# Altium schematic sheet 04-PS2.SchDoc
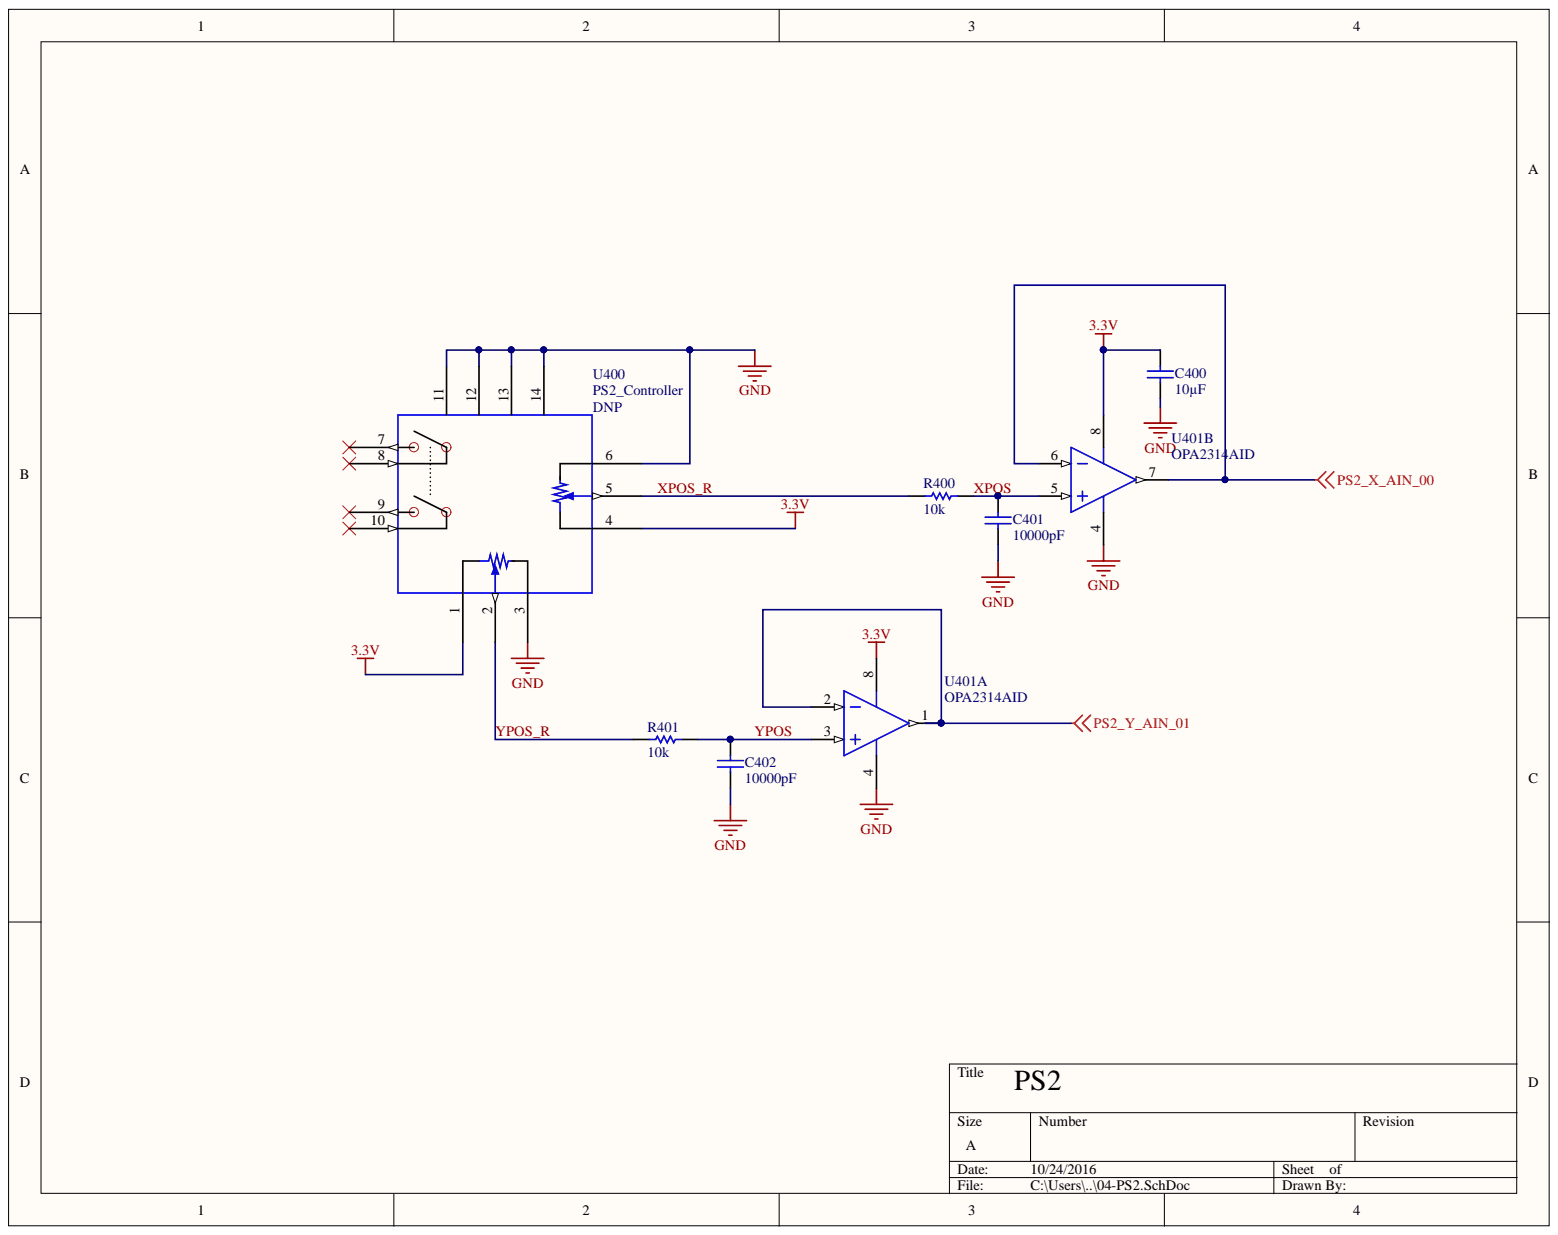
[diagram p0: 04-PS2.SchDoc]

## Parts
C400  10µF  [0805]
C401  10000pF  [CAP_0805]
C402  10000pF  [CAP_0805]
R400  10k  [RES_0805]
R401  10k  [RES_0805]
U400  PS2_Controller  Usage: DNP  [PS2_Controller]
U401  OPA2314AID  [TI-D8_N]  (parts A,B)

## Nets
3.3V: C400.2 U400.1 U400.4 U401.8
GND: C400.1 C401.1 C402.1 U400.3 U400.6 U400.11 U400.12 U400.13 U400.14 U401.4
PS2_X_AIN_00: U401.6 U401.7
PS2_Y_AIN_01: U401.1 U401.2
XPOS: C401.2 R400.1 U401.5
XPOS_R: R400.2 U400.5
YPOS: C402.2 R401.1 U401.3
YPOS_R: R401.2 U400.2

Not connected: U400 7 8 9 10

## Library data of the parts
C400 = CAP_0805_U_010.000: CAP CER 10UF 16V 10% X5R 0805; Manufacturer: Murata Electronics North America; Manufacturer Part Number: GRM21BR61C106KE15L; Applications: General Purpose; Capacitance: 10µF; Category: Capacitors; Component Kind: Standard; Features: -; Height - Seated (Max): -; Lead Spacing: -; Lead Style: -; Library Name: ece353-2016.SchLib; Mounting Type: Surface Mount, MLCC; Operating Temperature: -55°C ~ 85°C; Package / Case: 0805 (2012 Metric); Packaging: Cut Tape (CT); Ratings: -; RoHS: RoHS Compliant; Size / Dimension: 0.079" L x 0.049" W (2.00mm x 1.25mm); Supplier: Digi-Key; Supplier 1: Digi-Key; Supplier Part Number: 490-3886-1-ND; Supplier Part Number 1: 490-3886-1-ND; Temperature Coefficient: X5R; Thickness (Max): 0.053" (1.35mm); Tolerance: ±10%; Value: 10µF; Voltage - Rated: 16V
C401 C402 = CAP_0805_N_010.000: CAP CER 10NF 16V 10% X7R 0805; Manufacturer: Kemet; Manufacturer Part Number: C0805C103K4RACTU; Applications: General Purpose; Capacitance: 10000pF; Category: Capacitors; Component Kind: Standard; Features: -; Height - Seated (Max): -; Lead Spacing: -; Lead Style: -; Library Name: ece353-2016.SchLib; Mounting Type: Surface Mount, MLCC; Operating Temperature: -55°C ~ 125°C; Package / Case: 0805 (2012 Metric); Packaging: Cut Tape (CT); Ratings: -; RoHS: RoHS Compliant; Size / Dimension: 0.079" L x 0.049" W (2.00mm x 1.25mm); Supplier: Digi-Key; Supplier 1: Digi-Key; Supplier Part Number: 399-7995-1-ND; Supplier Part Number 1: 399-7995-1-ND; Temperature Coefficient: X7R; Thickness (Max): 0.035" (0.88mm); Tolerance: ±10%; Value: 10000pF; Voltage - Rated: 16V
R400 R401 = RES_0805_K_010.00: RES 10K 1/10W 1% 0805 SMD; Manufacturer: Panasonic Electronic Components; Manufacturer Part Number: ERJ-6ENF1002V; Power (Watts): 0.125W, 1/8W; Temperature Coefficient: ±100ppm/°C; Category: Resistors; Component Kind: Standard; Composition: Thick Film; Features: Automotive AEC-Q200; Height: 0.028" (0.70mm); Library Name: ece353-2016.SchLib; Number of Terminations: 2; Operating Temperature: -55°C ~ 155°C; Package / Case: 0805 (2012 Metric); Packaging: Cut Tape (CT); Resistance: 10k; RoHS: RoHS Compliant; Size / Dimension: 0.079" L x 0.049" W (2.00mm x 1.25mm); Supplier: Digi-Key; Supplier 1: Digi-Key; Supplier Device Package: 0805; Supplier Part Number: P10.0KCCT-ND; Supplier Part Number 1: P10.0KCCT-ND; Tolerance: ±1%; Value: 10k
U400 = PS2_Controller: Manufacturer Part Number: PS2 EBAY; Component Kind: Standard; Library Name: ece353-2016.SchLib
U401 = OPA2314AID: Dual, 3 MHz, Low-Power, Low-Noise, RR I/O, CMOS Operational Amplifier, 1.8 to 5.5 V, -40 to 125 degC, 8-pin SOIC (D8), Green (RoHS & no Sb/Br); Manufacturer: Texas Instruments; Manufacturer Part Number: OPA2314AIDR; Amplifier Type: General Purpose; Current - Input Bias: 0.2pA; Current - Output / Channel: 20mA; Current - Supply: 150µA; Gain Bandwidth Product: 3MHz; Number of Circuits: 2; Output Type: Rail-to-Rail; Slew Rate: 1.5 V/µs; Voltage - Input Offset: 500µV; Voltage - Supply, Single/Dual (±): 1.8 V ~ 5.5 V, ±0.9 V ~ 2.75 V; -3db Bandwidth: -; Category: Integrated Circuits (ICs); Component Kind: Standard; Library Name: ece353-2016.SchLib; Mounting Type: Surface Mount; Operating Temperature: -40°C ~ 125°C; Package / Case: 8-SOIC (0.154", 3.90mm Width); Packaging: Cut Tape (CT); RoHS: RoHS Compliant; Supplier: Digi-Key; Supplier 1: Digi-Key; Supplier Device Package: 8-SOIC; Supplier Part Number: 296-36697-1-ND; Supplier Part Number 1: 296-36697-1-ND
